# Altium schematic sheet STM32F103C8T6接口&扩展板_电源.SchDoc
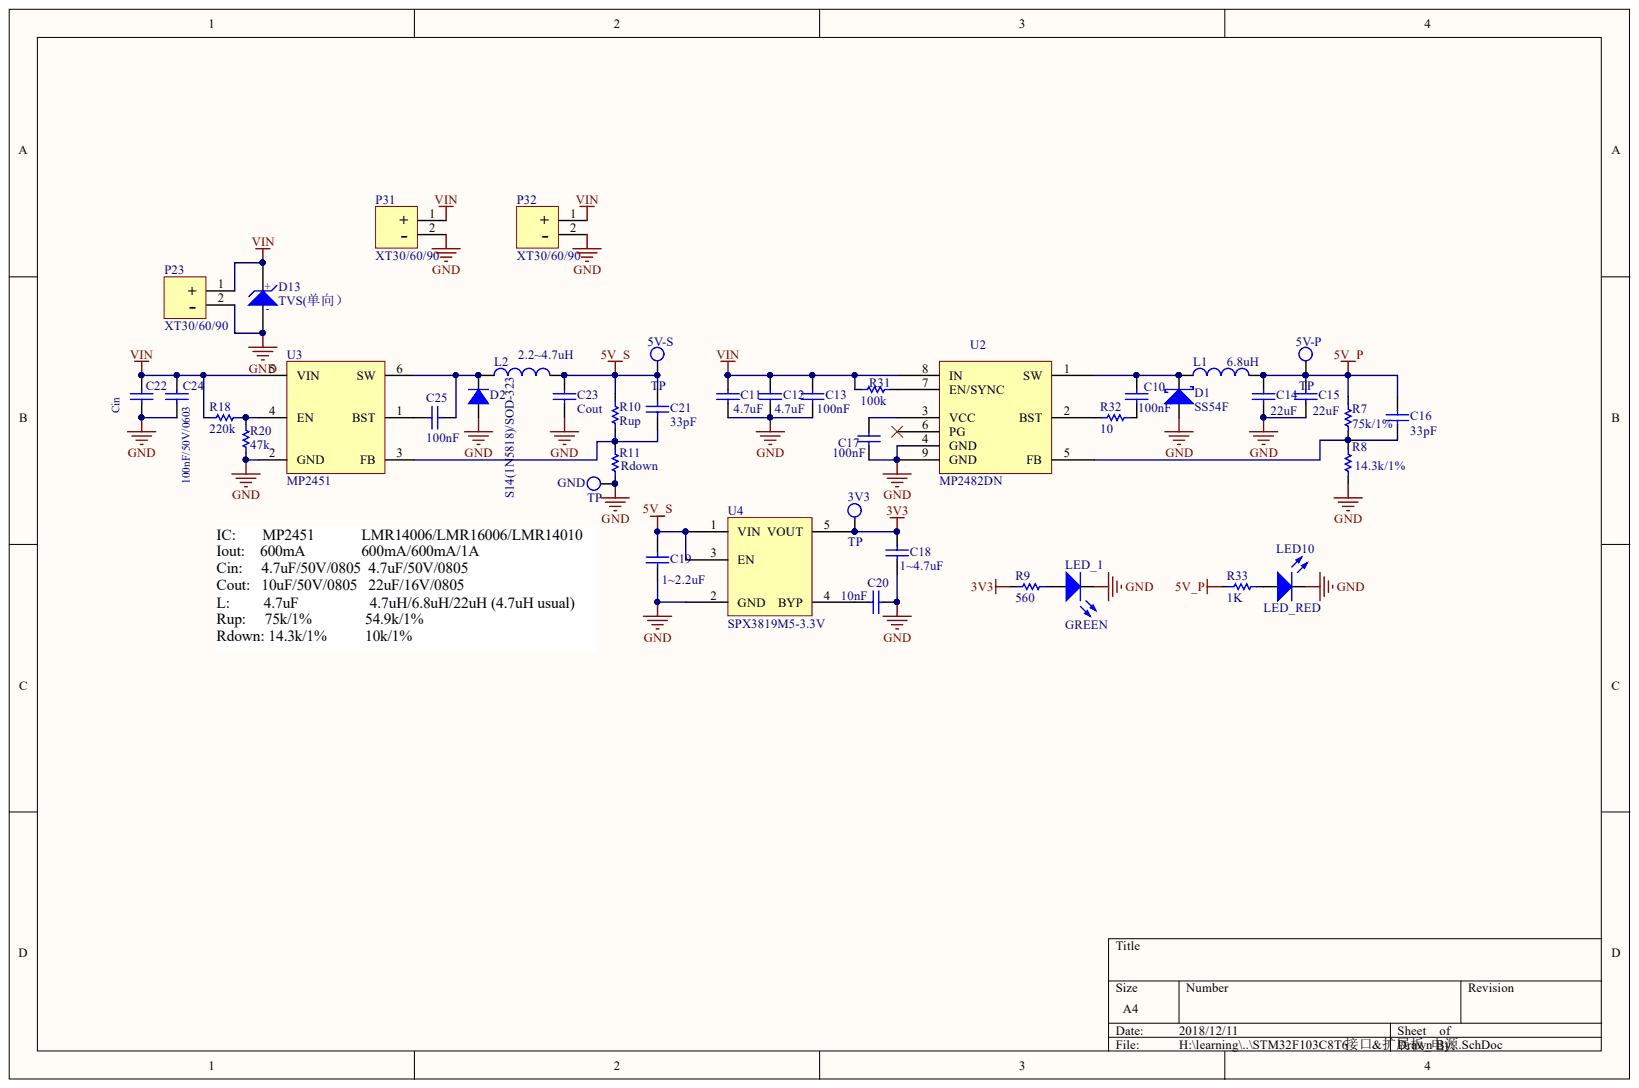
[diagram p0: STM32F103C8T6接口&扩展板_电源.SchDoc]

## Parts
3V3  TP  [TP_SMT]
5V-P  TP  [TP_SMT]
5V-S  TP  [TP_SMT]
C10  100nF  [0603C]
C11  4.7uF  [0805]
C12  4.7uF  [0805]
C13  100nF  [0603C]
C14  22uF  [0805]
C15  22uF  [0805]
C16  33pF  [0603C]
C17  100nF  [0603C]
C18  1~4.7uF  [0603C]
C19  1~2.2uF  [0603C]
C20  10nF  [0603C]
C21  33pF  [0603C]
C22  Cin  [0805]
C23  Cout  [0805]
C24  100nF/50V/0603  [0603C]
C25  100nF  [0603C]
D1  SS54F  [SMAF/DO-214AC]
D2  S14(1N5818)/SOD-323  [SOD-323-]
D13  TVS(单向）  [SMA/DO-214AC]
GND  TP  [TP_VIA]
L1  6.8uH  [0630L]
L2  2.2~4.7uH  [0420L]
LED10  LED_RED  [0603LED_B]
LED_1  GREEN  [0603LED_G]
P23  XT30/60/90  [XT30PW-Male]
P31  XT30/60/90  [XT30立式]
P32  XT30/60/90  [XT30立式]
R7  75k/1%  [0603R]
R8  14.3k/1%  [0603R]
R9  560  [0603R]
R10  Rup  [0603R]
R11  Rdown  [0603R]
R18  220k  [0603R]
R20  47k  [0603R]
R31  100k  [0603R]
R32  10  [0603R]
R33  1K  [0603R]
U2  MP2482DN  [SOIC8E]
U3  MP2451  [SOT23-6L]
U4  SPX3819M5-3.3V  [SOT-23-5 L]

## Nets
3V3: 3V3.1 C18.1 R9.2 U4.5(VOUT)
5V_P: 5V-P.1 C14.2 C15.2 C16.2 L1.2 R7.2 R33.2
5V_S: 5V-S.1 C19.2 C21.2 C23.2 L2.2 R10.2 U4.1(VIN) U4.3(EN)
GND: C11.1 C12.1 C13.1 C14.1 C15.1 C17.2 C18.2 C19.1 C20.1 C22.1 C23.1 C24.1 D1.1 D2.1 D13.1 GND.1 LED10.2 LED_1.2 P23.2 P31.2 P32.2 R8.1 R11.1 R20.1 U2.4(GND) U2.9(GND) U3.2(GND) U4.2(GND)
VIN: C11.2 C12.2 C13.2 C22.2 C24.2 D13.2 P23.1 P31.1 P32.1 R18.1 R31.1 U2.8(IN) U3.5(VIN)
NetC10_1: C10.1 R32.1
NetC10_2: C10.2 D1.2 L1.1 U2.1(SW)
NetC16_1: C16.1 R7.1 R8.2 U2.5(FB)
NetC17_1: C17.1 U2.3(VCC)
NetC20_2: C20.2 U4.4(BYP)
NetC21_1: C21.1 R10.1 R11.2 U3.3(FB)
NetC25_1: C25.1 D2.2 L2.1 U3.6(SW)
NetC25_2: C25.2 U3.1(BST)
NetLED10_1: LED10.1 R33.1
NetLED_1_1: LED_1.1 R9.1
NetR18_2: R18.2 R20.2 U3.4(EN)
NetR31_2: R31.2 U2.7(EN/SYNC)
NetR32_2: R32.2 U2.2(BST)

Not connected: U2 6(PG)

## Library data of the parts
3V3 5V-P 5V-S GND = TP: Test Point; Component Kind: Standard; Library Name: MyComponents_v2.4.IntLib
C10-C25 = Cap: Capacitor; Value: 100pF; Component Kind: Standard; LatestRevisionDate: 17-Jul-2002; LatestRevisionNote: Re-released for DXP Platform.; PackageDescription: Radial Cap, Thru-Hole; 2 Leads; 0.3 in Pin Spacing; PackageReference: RAD-0.3; Published: 8-Jun-2000; Signal Integrity: Cap; Simulation: CAP; Publisher: Altium Limited
  C10-C17: Library Name: MyComponents_v2.3.IntLib
  C18-C23 C25: Library Name: MyComponents_v2.4.IntLib
  C24: Library Name: MyComponents.IntLib
D1 = D Schottky_SMAF: Schottky Diode; Code_JEDEC: DO-214-AA; Component Kind: Standard; LatestRevisionDate: 17-Jul-2002; LatestRevisionNote: Re-released for DXP Platform.; Library Name: MyComponents_v2.5.IntLib; PackageDescription: DO-214-AA/SMB; 2 C-Bend Leads; Body 5.3 x 3.6 mm, inc. leads (LxW); PackageReference: SMB; Published: 8-Jun-2000; Signal Integrity: D Schottky; Simulation: SKYDIODE; Publisher: Altium Limited
D2 = Diode: Default Diode; Code_JEDEC: DO-214-AB; Component Kind: Standard; LatestRevisionDate: 17-Jul-2002; LatestRevisionNote: Re-released for DXP Platform.; Library Name: MyComponents_v2.4.IntLib; PackageDescription: DO-214-AB/SMC; 2 C-Bend Leads; Body 7.9 x 5.9 mm, inc. leads (LxW); PackageReference: SMC; PackageVersion: Sep-1996; Published: 8-Jun-2000; Signal Integrity: Diode; Simulation: DIODE; Publisher: Altium Limited
L1 L2 = Inductor: Code_IEC: 1005; Value: 10mH; Code_JEITA: 0402; Component Kind: Standard; Description: Inductor; LatestRevisionDate: 29-May-2009; LatestRevisionNote: IPC-7351 Footprint Added.; PackageDescription: Chip Inductor; PackageReference: 0402-A; Published: 8-Jun-2000; Signal Integrity: Inductor; Simulation: INDUCTOR; Publisher: Altium Limited
  L1: Library Name: MyComponents_v2.3.IntLib
  L2: Library Name: MyComponents_v2.5.IntLib
LED10 LED_1 = LED: Typical RED, GREEN, YELLOW, AMBER GaAs LED; Component Kind: Standard; LatestRevisionDate: 17-Jul-2002; LatestRevisionNote: Re-released for DXP Platform.; PackageDescription: SM LED; 2 Flat Leads; PackageReference: 3.2X1.6X1.1; PackageVersion: Feb-2002; Published: 8-Jun-2000; Simulation: LED2; Publisher: Altium Limited
  LED10: Library Name: MyComponents_v2.5.IntLib
  LED_1: Library Name: MyComponents.IntLib
R7-R11 R18 R20 R31 R32 = Res1: Resistor; Value: 1K; Component Kind: Standard; LatestRevisionDate: 17-Jul-2002; LatestRevisionNote: Re-released for DXP Platform.; PackageDescription: Axial Device, Thru-Hole; 2 Leads; 0.3 in Pin Spacing; PackageReference: AXIAL-0.3; Published: 8-Jun-2000; Signal Integrity: Res; Simulation: RESISTOR; Publisher: Altium Limited
  R7 R8 R31 R32: Library Name: MyComponents_v2.3.IntLib
  R9: Library Name: MyComponents.IntLib
  R10 R11 R18 R20: Library Name: MyComponents_v2.4.IntLib
R33 = Res1: Resistor; Component Kind: Standard; LatestRevisionDate: 17-Jul-2002; LatestRevisionNote: Re-released for DXP Platform.; Library Name: MyComponents_v2.5.IntLib; PackageDescription: Axial Device, Thru-Hole; 2 Leads; 0.3 in Pin Spacing; PackageReference: AXIAL-0.3; Published: 8-Jun-2000; Signal Integrity: Res; Simulation: RESISTOR; Value: 1K; Publisher: Altium Limited
U2 = MP2482DN: 开关电源5A; Component Kind: Standard; Library Name: MyComponents_v2.3.IntLib
U3 = MP2451: 开关电源0.6A; Component Kind: Standard; Library Name: MyComponents_v2.4.IntLib
U4 = SPX5205M5-3.3V: Component Kind: Standard; Library Name: MyComponents_v2.4.IntLib
D13 = TVS(单向）: Component Kind: Standard; Library Name: MyComponents_v2.4.IntLib
P23 P31 P32 = XT30/60/90: Component Kind: Standard
  P23: Library Name: MyComponents_v2.4.IntLib
  P31 P32: Library Name: MyComponents_v2.5.IntLib
